# Altium schematic sheet Power.SchDoc(Power)
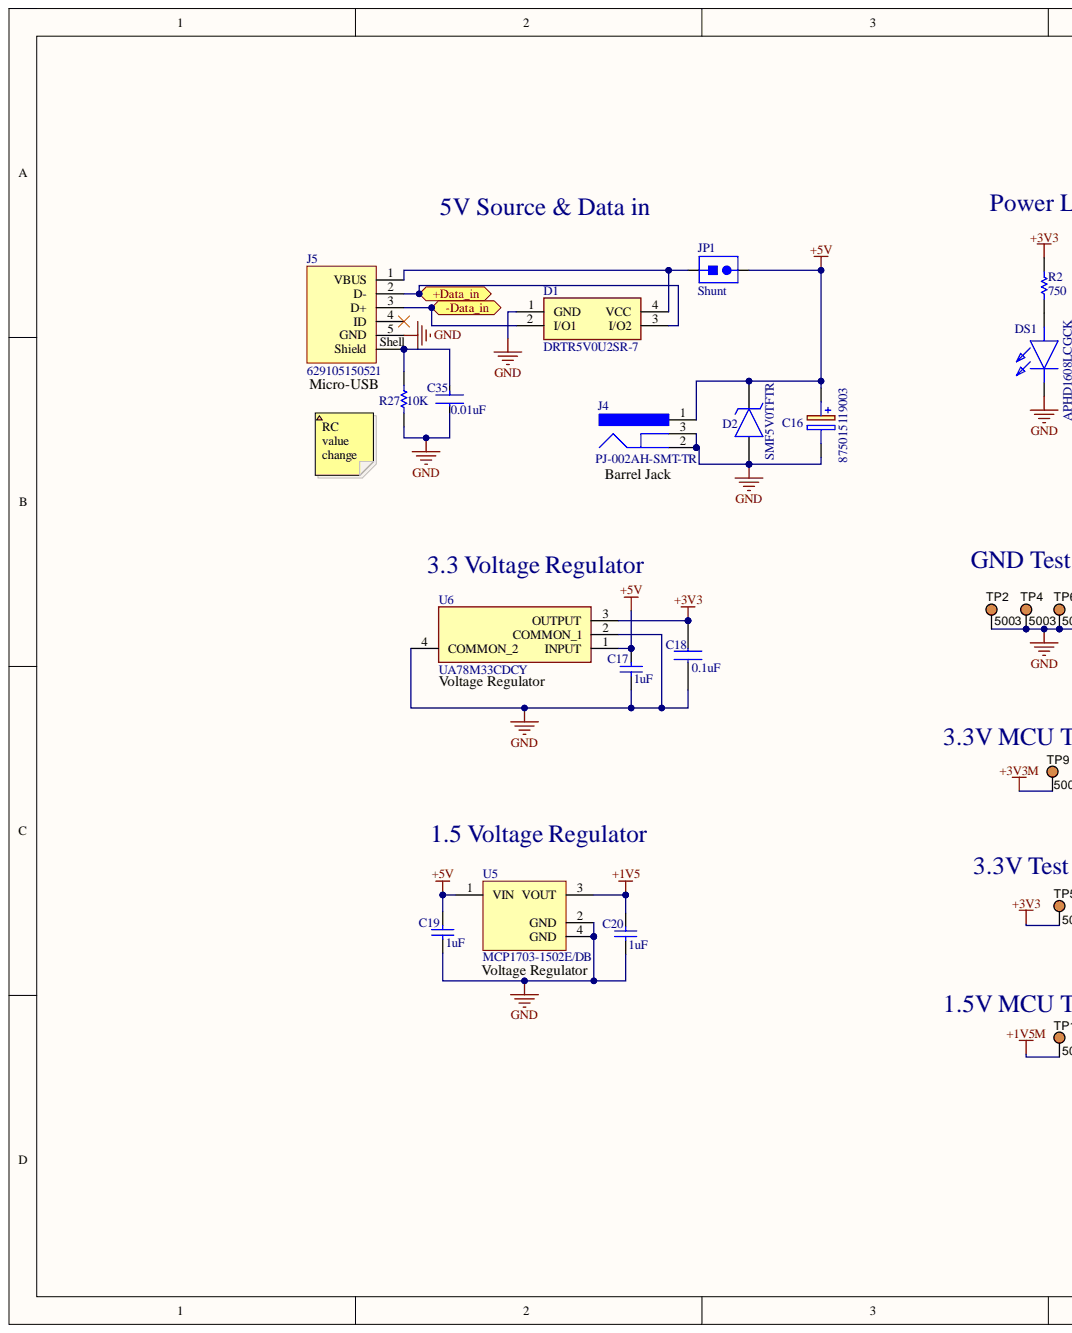
[diagram p0: Power.SchDoc, left]
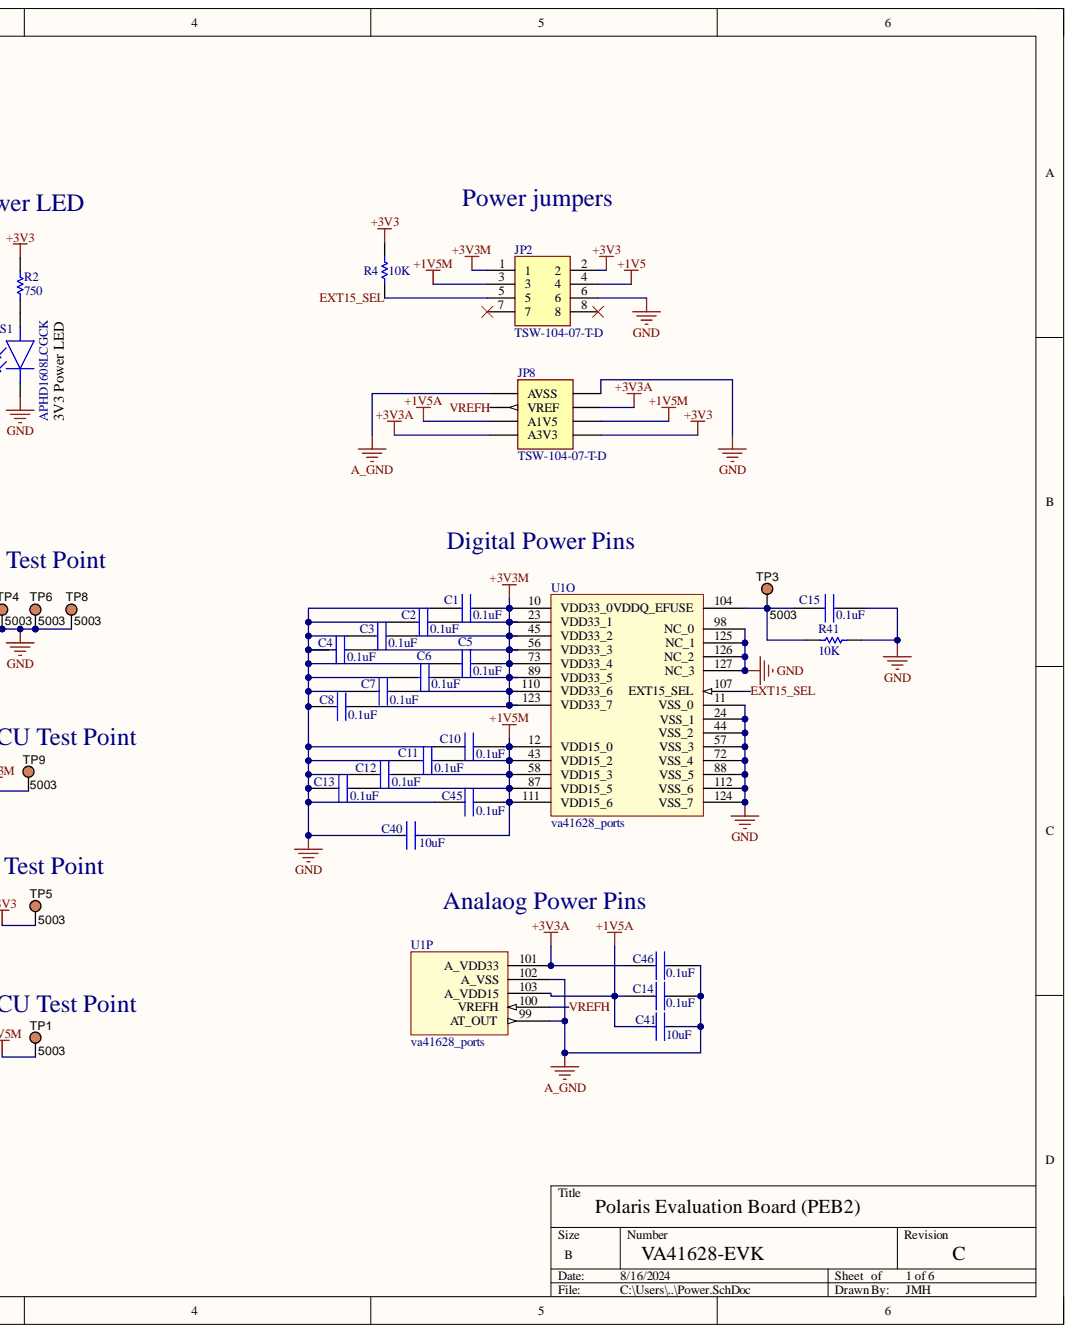
[diagram p1: Power.SchDoc, right]

## Parts
C1  Parameter 1: 0.1uF  [C0603C104K3RAC7013]  (hidden comment: C0603C104K3RAC7013)
C2  Parameter 1: 0.1uF  [C0603C104K3RAC7013]  (hidden comment: C0603C104K3RAC7013)
C3  Parameter 1: 0.1uF  [C0603C104K3RAC7013]  (hidden comment: C0603C104K3RAC7013)
C4  Parameter 1: 0.1uF  [C0603C104K3RAC7013]  (hidden comment: C0603C104K3RAC7013)
C5  Parameter 1: 0.1uF  [C0603C104K3RAC7013]  (hidden comment: C0603C104K3RAC7013)
C6  Parameter 1: 0.1uF  [C0603C104K3RAC7013]  (hidden comment: C0603C104K3RAC7013)
C7  Parameter 1: 0.1uF  [C0603C104K3RAC7013]  (hidden comment: C0603C104K3RAC7013)
C8  Parameter 1: 0.1uF  [C0603C104K3RAC7013]  (hidden comment: C0603C104K3RAC7013)
C10  Parameter 1: 0.1uF  [C0603C104K3RAC7013]  (hidden comment: C0603C104K3RAC7013)
C11  Parameter 1: 0.1uF  [C0603C104K3RAC7013]  (hidden comment: C0603C104K3RAC7013)
C12  Parameter 1: 0.1uF  [C0603C104K3RAC7013]  (hidden comment: C0603C104K3RAC7013)
C13  Parameter 1: 0.1uF  [C0603C104K3RAC7013]  (hidden comment: C0603C104K3RAC7013)
C14  Parameter 1: 0.1uF  [C0603C104K3RAC7013]  (hidden comment: C0603C104K3RAC7013)
C15  Parameter 1: 0.1uF  [C0603C104K3RAC7013]  (hidden comment: C0603C104K3RAC7013)
C16  875015119003  [TPSD107M016T0080V]
C17  Parameter 1: 1uF  [C0603C105K9RAC7411]  (hidden comment: C0603C105K3RACTU)
C18  Parameter 1: 0.1uF  [C0603C104K3RAC7013]  (hidden comment: C0603C104K3RAC7013)
C19  Parameter 1: 1uF  [C0603C105K9RAC7411]  (hidden comment: C0603C105K3RACTU)
C20  Parameter 1: 1uF  [C0603C105K9RAC7411]  (hidden comment: C0603C105K3RACTU)
C35  value 0.01uF  [C0603C103K5RACAUTO7411]  (hidden comment: C0603C103K1RAC7411)
C40  value 10uF  Capacitance: 10uF  [C0805C106K8PACTU]  (hidden comment: C0805C106K8PACTU)
C41  value 10uF  Capacitance: 10uF  [C0805C106K8PACTU]  (hidden comment: C0805C106K8PACTU)
C45  Parameter 1: 0.1uF  [C0603C104K3RAC7013]  (hidden comment: C0603C104K3RAC7013)
C46  Parameter 1: 0.1uF  [C0603C104K3RAC7013]  (hidden comment: C0603C104K3RAC7013)
D1  DRTR5V0U2SR-7  [DRTR5V0U2SR-7]
D2  SMF5V0TFTR  [5wv Trans UNI]
DS1  APHD1608LCGCK  [APHD1608LCGCK]
J4  PJ-002AH-SMT-TR  [PJ-002AH-SMT-TR_PJ-002AH-SMT-TR(Primary)]
J5  629105150521  [629105150521_629105150521(Primary)]
JP1  Shunt  [2302-6121TG]
JP2  TSW-104-07-T-D  [TSW-104-07-G-D]
JP8  TSW-104-07-T-D  [TSW-104-07-G-D]
R2  CRCW0603750RFKEA  [CRCW0603750RJNEA]  (value not drawn)
R4  Parameter 1: 10K  [CRCW060310K0FKEAC]  (hidden comment: CRCW060310K0FKEA)
R27  Parameter 1: 10K  [CRCW060310K0FKEAC]  (hidden comment: CRCW060310K0FKEA)
R41  Parameter 1: 10K  [CRCW060310K0FKEAC]  (hidden comment: CRCW060310K0FKEA)
TP1  5003  [TEST PIN stand off]
TP2  5003  [TEST PIN stand off]
TP3  5003  [TEST Point]
TP4  5003  [TEST PIN stand off]
TP5  5003  [TEST PIN stand off]
TP6  5003  [TEST PIN stand off]
TP8  5003  [TEST PIN stand off]
TP9  5003  [TEST PIN stand off]
U1  va41628_ports  [TCQFP-128-0.40-36-C]  (parts O,P)
U5  MCP1703-1502E/DB  [MCP1703-1502E_DB]
U6  UA78M33CDCY  [UA78M33CDCY]

## Ports
+Data_in, -Data_in

## Nets
+1V5: C20.1 JP2.4 U5.3(VOUT)
+1V5A: C14.1 C41.1 JP8.5(A1V5) U1.103(A_VDD15)
+1V5M: C10.1 C11.1 C12.1 C13.1 C40.1 C45.1 JP2.3 JP8.6 TP1.1 U1.12(VDD15_0) U1.43(VDD15_2) U1.58(VDD15_3) U1.87(VDD15_5) U1.111(VDD15_6)
+3V3: C18.1 JP2.2 JP8.8 R2.1 R4.2 TP5.1 U6.3(OUTPUT)
+3V3A: C46.1 JP8.4 JP8.7(A3V3) U1.101(A_VDD33)
+3V3M: C1.1 C2.1 C3.1 C4.1 C5.1 C6.1 C7.1 C8.1 JP2.1 TP9.1 U1.10(VDD33_0) U1.23(VDD33_1) U1.45(VDD33_2) U1.56(VDD33_3) U1.73(VDD33_4) U1.89(VDD33_5) U1.110(VDD33_6) U1.123(VDD33_7)
+5V: C16.1 C17.1 C19.1 D2.1 J4.1 JP1.2 U5.1(VIN) U6.1(INPUT)
A_GND: C14.2 C41.2 C46.2 JP8.1(AVSS) U1.99(AT_OUT) U1.102(A_VSS)
GND: C1.2 C2.2 C3.2 C4.2 C5.2 C6.2 C7.2 C8.2 C10.2 C11.2 C12.2 C13.2 C15.2 C16.2 C17.2 C18.2 C19.2 C20.2 C35.2 C40.2 C45.2 D1.1(GND) D2.2 DS1.1 J4.2 J4.3 J5.5(GND) JP2.6 JP8.2 R27.2 R41.2 TP2.1 TP4.1 TP6.1 TP8.1 U1.11(VSS_0) U1.24(VSS_1) U1.44(VSS_2) U1.57(VSS_3) U1.72(VSS_4) U1.88(VSS_5) U1.98(NC_0) U1.112(VSS_6) U1.124(VSS_7) U1.125(NC_1) U1.126(NC_2) U1.127(NC_3) U5.2(GND) U5.4(GND) U6.2(COMMON_1) U6.4(COMMON_2)
EXT15_SEL: JP2.5 R4.1 U1.107(EXT15_SEL)
VREFH: JP8.3(VREF) U1.100(VREFH)
NetC15_1: C15.1 R41.1 TP3.1 U1.104(VDDQ_EFUSE)
NetC35_1: C35.1 J5.Shell(Shield) R27.1
NetD1_2: D1.2(I/O1) J5.3(D+)
NetD1_3: D1.3(I/O2) J5.2(D-)
NetD1_4: D1.4(VCC) J5.1(VBUS) JP1.1
NetDS1_2: DS1.2 R2.2

Not connected: J5 4(ID); JP2 7 8

## Library data of the parts
C1-C8 C10-C15 C18 C45 C46 = C0603C104K3RAC7013: Capacitor; Manufacturer_Name: KEMET; Component Kind: Standard; Datasheet Link: https://www.kemet.com/specsheet/C0603C104K3RACTM; Height: 0.95mm; Library Name: SamacSys.SchLib; Manufacturer_Part_Number: C0603C104K3RAC7013
C16 = 875015119003: Capacitor Polarised; Manufacturer_Name: Wurth Elektronik; Component Kind: Standard; Datasheet Link: https://katalog.we-online.com/pbs/datasheet/875015119003.pdf; Height: 2mm; Manufacturer_Part_Number: 875015119003; Mouser Part Number: 710-875015119003; Mouser Price/Stock: https://www.mouser.co.uk/ProductDetail/Wurth-Elektronik/875015119003?qs=l7cgNqFNU1iintCU1azfcg%3D%3D
C17 C19 C20 = CMP-2006-03713-1: Manufacturer: Kemet; Component Kind: Standard; Library Name: Altium Content Vault; Part Number: C0603C105K3RACTU
C35 = CMP-03020-028119-1: C0603 10,000 pF X7R 30ppm/°C 10.00% 100 V; Manufacturer: KEMET; Automotive Grade: Grade 1; Material: Ceramic; Tolerance: 0.1; Voltage Rating: 100 V; Automotive: Yes; Capacitance: 10,000 pF; Category: Cap; Component Kind: Standard; ComponentLink2Description: Datasheet; ComponentLink2URL: https://api.kemet.com/component-edge/download/datasheet/C0603C100J5GACAUTO.pdf; Device Class L1: Passive Components; Device Class L2: Capacitors; Device Class L3: Ceramic Capacitors; Height: 0.87mm; Lead Free: Yes; Library Name: Altium Content Vault; Manufacturer Part Number: C0603C103K1RAC7411; Octopart: https://octopart.com/c0603c103k1rac7411-kemet-20760356; Package: C0603C-CF; RoHS: TRUE; Temperature: -55°C to +125°C; Temperature Characteristic: X7R; Temperature Coefficient: 30ppm/°C; Temperature Range High: +125°C; Temperature Range Low: -55°C
C40 C41 = CMP-2007-03243-2: CAP CER 10UF 10V X5R 0805; 3DS Part Number: 220-00001; Manufacturer: Kemet; Material: Ceramic; Temperature Coefficient: 15%; Tolerance: 10%; Automotive: No; Category: Cap; Component Kind: Standard; ComponentLink2Description: Datasheet; ComponentLink2URL: https://content.kemet.com/datasheets/KEM_C1006_X5R_SMD.pdf; Device Class L1: Passive Components; Device Class L2: Capacitors; Device Class L3: Ceramic Capacitors; Digikey Description: CAP CER 10UF 10V X5R 0805; Height: 1.4mm; Ipc Land Pattern Name: CAPC200125X125; Lead Free: Yes; Library Name: Altium Content Vault; Manufacturer Part Number: C0805C106K8PACTU; Mouser Description: CAP CER 10UF 10V X5R 0805; Octopart: https://octopart.com/c0805c106k8pactu-kemet-98697; Package: C0805C-DG; RoHS: TRUE; Temperature: -55°C to +85°C; Temperature Characteristic: X5R; Temperature Range High: +85°C; Temperature Range Low: -55°C; Voltage: 10V; Voltage Rating: 10V
D1 = DRTR5V0U2SR-7: TVS Diode (Uni-directional); Manufacturer_Name: Diodes Inc.; Arrow Part Number: DRTR5V0U2SR-7; Arrow Price/Stock: https://www.arrow.com/en/products/drtr5v0u2sr-7/diodes-incorporated?region=nac; Component Kind: Standard; Datasheet Link: https://www.diodes.com/assets/Datasheets/DRTR5V0U2SR.pdf; Height: 1.1mm; Manufacturer_Part_Number: DRTR5V0U2SR-7; Mouser Part Number: 621-DRTR5V0U2SR-7; Mouser Price/Stock: https://www.mouser.co.uk/ProductDetail/Diodes-Incorporated/DRTR5V0U2SR-7?qs=eSfX1CQlHqqi0ED%252B873yrw%3D%3D
D2 = SMF5V0TFTR: TVS Diode (Uni-directional); Manufacturer_Name: ROHM Semiconductor; Component Kind: Standard; Datasheet Link: https://fscdn.rohm.com/en/products/databook/datasheet/discrete/diode/zener/smf5v0tftr-e.pdf; Height: 0.9mm; Manufacturer_Part_Number: SMF5V0TFTR; Mouser Part Number: 755-SMF5V0TFTR; Mouser Price/Stock: https://www.mouser.co.uk/ProductDetail/ROHM-Semiconductor/SMF5V0TFTR?qs=HXFqYaX1Q2yovBAFID6n8A%3D%3D
DS1 = APHD1608LCGCK: LED; Manufacturer_Name: Kingbright; Arrow Part Number: APHD1608LCGCK; Arrow Price/Stock: https://www.arrow.com/en/products/aphd1608lcgck/kingbright?region=nac; Component Kind: Standard; Datasheet Link: http://www.kingbrightusa.com/images/catalog/SPEC/APHD1608LCGCK.pdf; Height: 0.8mm; Library Name: SamacSys.SchLib; Manufacturer_Part_Number: APHD1608LCGCK; Mouser Part Number: 604-APHD1608LCGCK; Mouser Price/Stock: https://www.mouser.co.uk/ProductDetail/Kingbright/APHD1608LCGCK?qs=AQlKX63v8RvqPbV1LDSVuw%3D%3D
J4 = CMP-2000-06896-2: DC Power Jack, Right Angle, -25 to 85 degC, 4-Pin 14.8 x 9 x 11 mm SMD, RoHS, Tape and Reel; Manufacturer: CUIInc.; Brand: CUI; Current Rating: 5A; Inside Contact Diameter: 2mm; Insulation Resistance: 100MOhms; Outside Contact Diameter: 6.5mm; Voltage Rating: 24V; Component Kind: Standard; Connector Type: DCPowerJack; Contact Gender: Male; Contact Material: Brass; Contact Plating: Nickel; Datasheet URL: http://www.cui.com/product/resource/pj-002ah-smt.pdf; Datasheet Version: Rev. 1.03, 11/2012; Factory Pack Quantity: 350; Flammability Rating: UL94V-0; Housing Material: Polyamide(PA); Library Name: Altium Content Vault; Manufacturer URL: http://www.cui.com/; Mounting Angle: Right; Mounting Style: SMD/SMT; Mounting Technology: SurfaceMount; Operating Temperature Range: -25Cto+85C; Package Description: 4-Pin Surface Mount Device, Body 14.8 x 9 mm, Pitch 6.1 mm; Package Reference: PJ-002AH; Packaging: Reel; PartNumber: PJ-002AH; Product: Jacks; Product Category: DCPowerConnectors; RoHS: True; Termination Style: SMD/SMT
J5 = CMP-1502-03252-3: NexarPartId: 52645113; Connector Type: USB; Contact Resistance: 30mΩ; Current Rating: 3A; Gender: Receptacle; Insulation Resistance: 1GΩ; Number of Contacts: 5; Operating Supply Voltage: 30V; USB Standard: USB 2.0; Component Kind: Standard; Contact Material: Gold; Contact Plating: Gold; Features: Shielded; Height: 2.86mm; Lead Free: Lead Free; Library Name: Altium Content Vault; Max Operating Temperature: 85°C; Min Operating Temperature: -40°C; Mount: Horizontal, Surface Mount; Number of Pins: 5; Orientation: Horizontal; Packaging: Tape and Reel; REACH SVHC: Unknown; RoHS: Compliant; Termination: SMD/SMT; Voltage Rating: 30V
JP1 = CMP-002-00087-4: 1x2 pin header; Component Kind: Standard; Library Name: Vorago Tech
JP2 JP8 = TSW-104-07-T-D: Connector; Manufacturer_Name: SAMTEC; Arrow Part Number: TSW-104-07-T-D; Arrow Price/Stock: https://www.arrow.com/en/products/tsw-104-07-t-d/samtec?region=europe; Component Kind: Standard; Datasheet Link: http://suddendocs.samtec.com/prints/tsw-xxx-xx-xxx-x-xx-xxx-mkt.pdf; Library Name: SamacSys.SchLib; Manufacturer_Part_Number: TSW-104-07-T-D; Mouser Part Number: 200-TSW10407TD; Mouser Price/Stock: https://www.mouser.co.uk/ProductDetail/Samtec/TSW-104-07-T-D?qs=hzq9O0YpnZtBZCcLzm8dag%3D%3D
R2 = CMP-2000-03568-1: Manufacturer: Vishay Dale; Res: 750; Component Kind: Standard; Library Name: Altium Content Vault; Part Number: CRCW0603750RFKEA
R4 R27 R41 = CMP-1666-00005-4: Chip Resistor, 10 KOhm, +/- 1%, 100 mW, -55 to 155 degC, 0603 (1608 Metric), RoHS, Tape and Reel; Manufacturer: Vishay Semiconductor; Voltage Rating: 75 V; Component Kind: Standard; ComponentLink1Description: Manufacturer URL; ComponentLink1URL: http://www.vishay.com/; ComponentLink2Description: Datasheet; ComponentLink2URL: https://datasheet.ciiva.com/11809/0900766b80d98afc-11809037.pdf; DatasheetVersion: 06/2012; Height: 0.45 mm; Length: 1.55 mm; Library Name: Altium Content Vault; Max Operating Temperature: 155 degC; Min Operating Temperature: -55 degC; Mounting Technology: Surface Mount; Package Quantity: 5000; PackageDescription: 2-Pin Surface Mount Device, Body 1.575 x 0.85 mm; PackageReference: 0603; Packaging: Tape and Reel; REACH SVHC: Unknown; RoHSCompliant: true
TP1-TP6 TP8 TP9 = CMP-002-00037-2: Test Point, Orange, Height 4.6 mm, Tail Length 3 mm, 1-Pin THD, RoHS; Manufacturer: Keystone; Case/Package: 5003; Colour: Orange; Component Kind: Standard; Connector Type: TestPoint; Contact Material: Bronze; Contact Plating: Tin; Datasheet URL: http://keyelco.com/userAssets/file/M65p56.pdf; Datasheet Version: Jun-15; Diameter: 2.54mm; Manufacturer URL: http://www.keyelco.com/; Mounting Technology: TH; Package Description: 1-Pin Through Hole Device, Dia 2.54 mm; Package Quantity: 10; Package Reference: 5003; Packaging: Bulk; Pins: 1; RoHS Compliant: True; Library Name: Vorago Tech
U1 = va41628_ports: Polaris Lite 128 pin; Component Kind: Standard; Library Name: va416xx.SchLib
U5 = CMP-0136-00525-1: 250 mA, 16V, Low Quiescent Current LDO Regulator, 3-Pin SOT-223, Extended Temperature; Component Kind: Standard; DatasheetVersion: revD, Sep-2009; Library Name: Altium Content Vault; PackageDescription: 3-Lead Plastic Small Outline Transistor (DB) [SOT-223]; PackageReference: SOT-223-DB3; PackageVersion: revBB, Aug-2009
U6 = UA78M33CDCY: Integrated Circuit; Manufacturer_Name: Texas Instruments; Arrow Part Number: UA78M33CDCY; Arrow Price/Stock: https://www.arrow.com/en/products/ua78m33cdcy/texas-instruments?region=nac; Component Kind: Standard; Datasheet Link: https://www.ti.com/lit/ds/symlink/ua78m.pdf?HQS=TI-null-null-mousermode-df-pf-null-wwe&ts=1607658455811&ref_url=https%253A%252F%252Fwww.mouser.fr%252F; Height: 1.8mm; Manufacturer_Part_Number: UA78M33CDCY; Mouser Part Number: 595-UA78M33CDCY; Mouser Price/Stock: https://www.mouser.co.uk/ProductDetail/Texas-Instruments/UA78M33CDCY?qs=sbcp%2F4gpy09US8tH%252B2FxOw%3D%3D
